# Altium schematic sheet sdrtckart.SchDoc
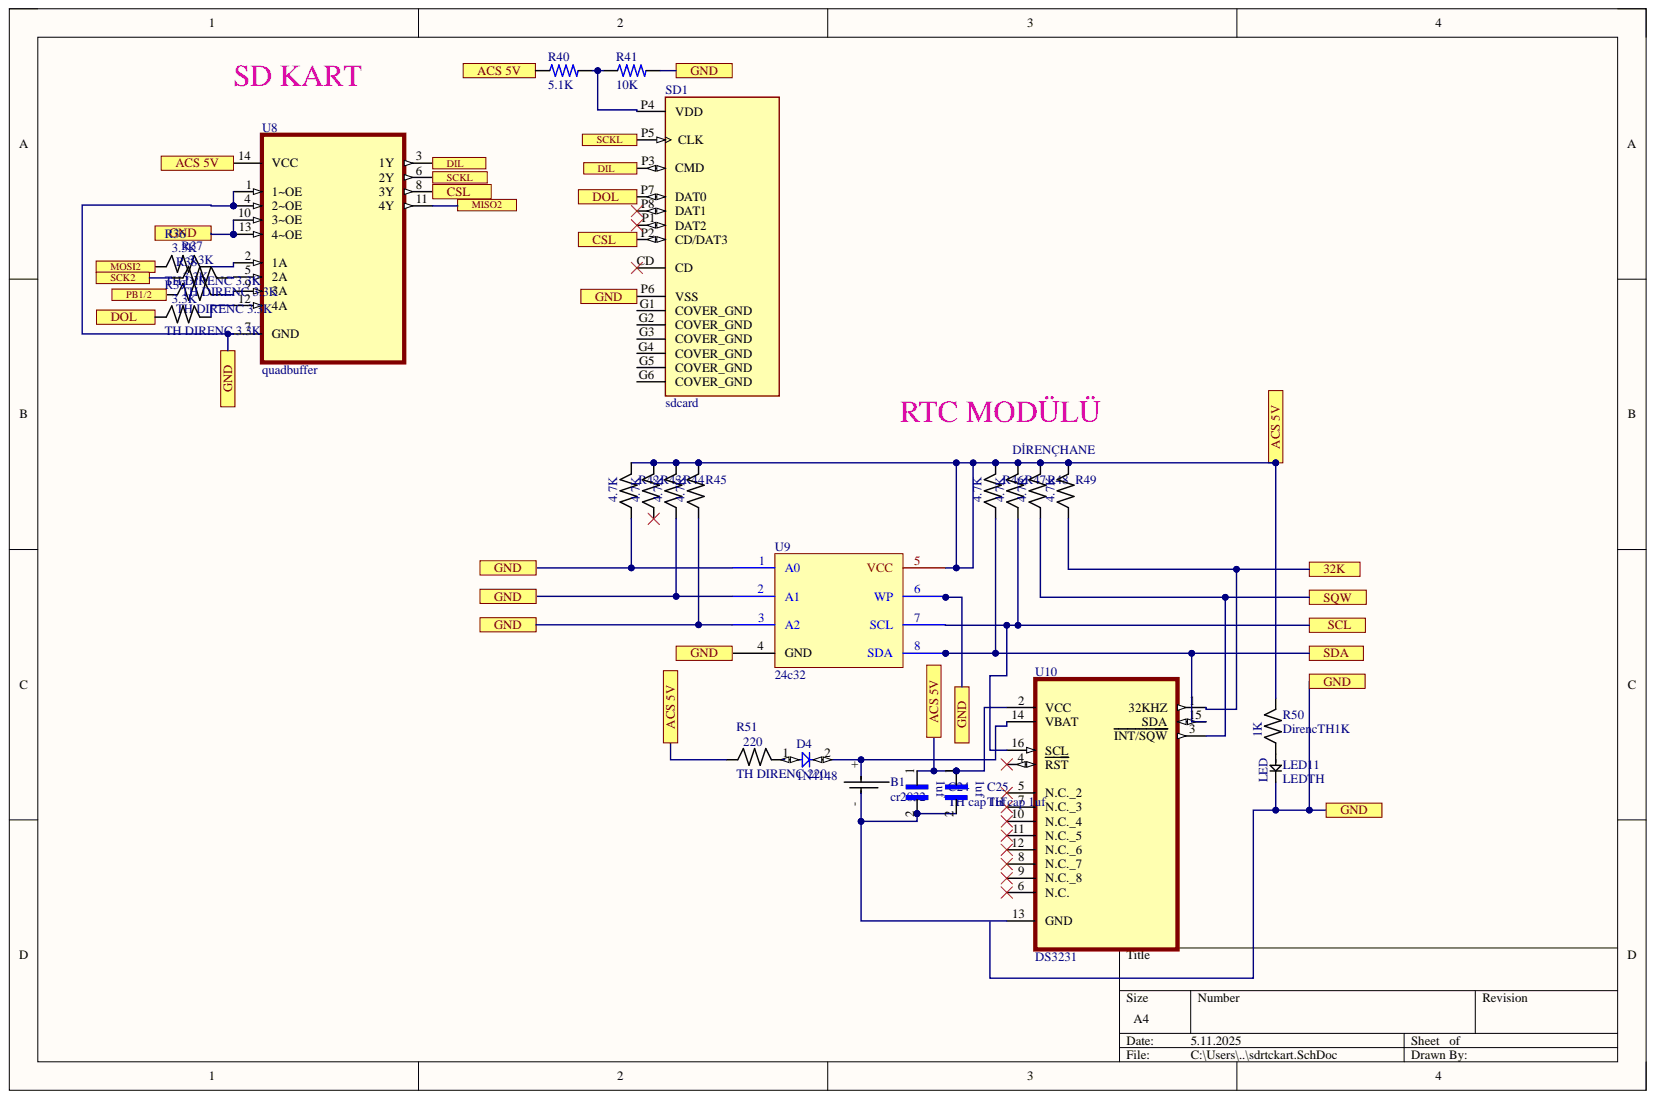
[diagram p0: sdrtckart.SchDoc]

## Parts
B1  cr2032  [vertical battery holder]
C24  TH cap 1uf  [Kapkucuk]
C25  TH cap 1uf  [Kapkucuk]
D4  1N4148  [1N4148]
LED11  LEDTH  [led3mm]
R36  TH DIRENC 3.3K  [resistor TH]
R37  TH DIRENC 3.3K  [resistor TH]
R38  TH DIRENC 3.3K  [resistor TH]
R39  TH DIRENC 3.3K  [resistor TH]
R40  5.1K  [resistor TH]
R41  10K  [resistor TH]
R42  TH Direnç 4.7K  [resistor TH]  (value not drawn)
R43  TH Direnç 4.7K  [resistor TH]  (value not drawn)
R44  TH Direnç 4.7K  [resistor TH]  (value not drawn)
R45  TH Direnç 4.7K  [resistor TH]  (value not drawn)
R46  TH Direnç 4.7K  [resistor TH]  (value not drawn)
R47  TH Direnç 4.7K  [resistor TH]  (value not drawn)
R48  TH Direnç 4.7K  [resistor TH]  (value not drawn)
R49  TH Direnç 4.7K  [resistor TH]  (value not drawn)
R50  DirencTH1K  [resistor TH]
R51  TH DIRENC 220  [resistor TH]
SD1  sdcard  [sdcard]
U8  quadbuffer  [dip 14]
U9  24c32  [dip8]
U10  DS3231  [soic16]

## Ports
32K, ACS 5V, CSL, DIL, DOL, GND, MISO2, MOSI2, PB1/2, SCK2, SCKL, SCL, SDA, SQW

## Nets
32K: R49.0 U10.1(32KHZ) ; port 32K
ACS 5V: C24.1 C25.1 R40.0 R42.1 R43.1 R44.1 R45.1 R46.1 R47.1 R48.1 R49.1 R50.1 R51.0 U8.14(VCC) U9.5(VCC) U10.2(VCC) ; port ACS 5V
CSL: SD1.P2(CD/DAT3) U8.8(3Y) ; port CSL
DIL: SD1.P3(CMD) U8.3(1Y) ; port DIL
DOL: R39.0 SD1.P7(DAT0) ; port DOL
GND: LED11.1 R41.1 R42.0 R44.0 R45.0 SD1.P6(VSS) U8.1(1~OE) U8.4(2~OE) U8.7(GND) U8.10(3~OE) U8.13(4~OE) U9.1(A0) U9.2(A1) U9.3(A2) U9.4(GND) U9.6(WP) ; port GND
MISO2: U8.11(4Y) ; port MISO2
MOSI2: R36.0 ; port MOSI2
PB1/2: R38.0 ; port PB1/2
SCK2: R37.0 ; port SCK2
SCKL: SD1.P5(CLK) U8.6(2Y) ; port SCKL
SCL: R47.0 U10.16(SCL) ; port SCL
SDA: R46.0 U9.8(SDA) U10.15(SDA) ; port SDA
SQW: R48.0 U10.3(INT/SQW) ; port SQW
NetB1_+: B1.+ D4.2 U10.14(VBAT)
NetB1_-: B1.- C24.2 C25.2 U10.13(GND)
NetD4_1: D4.1 R51.1
NetLED11_0: LED11.0 R50.0
NetR36_1: R36.1 U8.2(1A)
NetR37_1: R37.1 U8.5(2A)
NetR38_1: R38.1 U8.9(3A)
NetR39_1: R39.1 U8.12(4A)
NetR40_1: R40.1 R41.0 SD1.P4(VDD)

Not connected: R43 0; SD1 CD G1(COVER_GND) G2(COVER_GND) G3(COVER_GND) G4(COVER_GND) G5(COVER_GND) G6(COVER_GND) P1(DAT2) P8(DAT1); U9 7(SCL); U10 4(RST) 5(N.C._2) 6(N.C.) 7(N.C._3) 8(N.C._7) 9(N.C._8) 10(N.C._4) 11(N.C._5) 12(N.C._6)

## Library data of the parts
R40 R41 = resistor TH: Component Kind: Standard; Library Name: Copy of Parts.SchLib
B1 = cr2032: Component Kind: Standard; Library Name: Copy of Parts.SchLib
C24 C25 = TH cap 1uf: Component Kind: Standard; Library Name: Copy of Parts.SchLib
D4 = 1N4148: Component Kind: Standard; Library Name: Copy of Parts.SchLib
LED11 = LEDTH: Component Kind: Standard; Library Name: Copy of Parts.SchLib
R36-R39 = TH DIRENC 3.3K: Component Kind: Standard; Library Name: Copy of Parts.SchLib
R42-R49 = TH Direnç 4.7K: Component Kind: Standard; Library Name: Copy of Parts.SchLib
R50 = DirencTH1K: Component Kind: Standard; Library Name: Copy of Parts.SchLib
R51 = TH DIRENC 220: Component Kind: Standard; Library Name: Copy of Parts.SchLib
SD1 = sdcard: Component Kind: Standard; Library Name: Copy of Parts.SchLib
U8 = quadbuffer: Component Kind: Standard; Library Name: Copy of Parts.SchLib
U9 = 24c32: Component Kind: Standard; Library Name: Copy of Parts.SchLib
U10 = DS3231: Component Kind: Standard; Library Name: Copy of Parts.SchLib
